# Altium schematic sheet Sensor_template.SchDoc
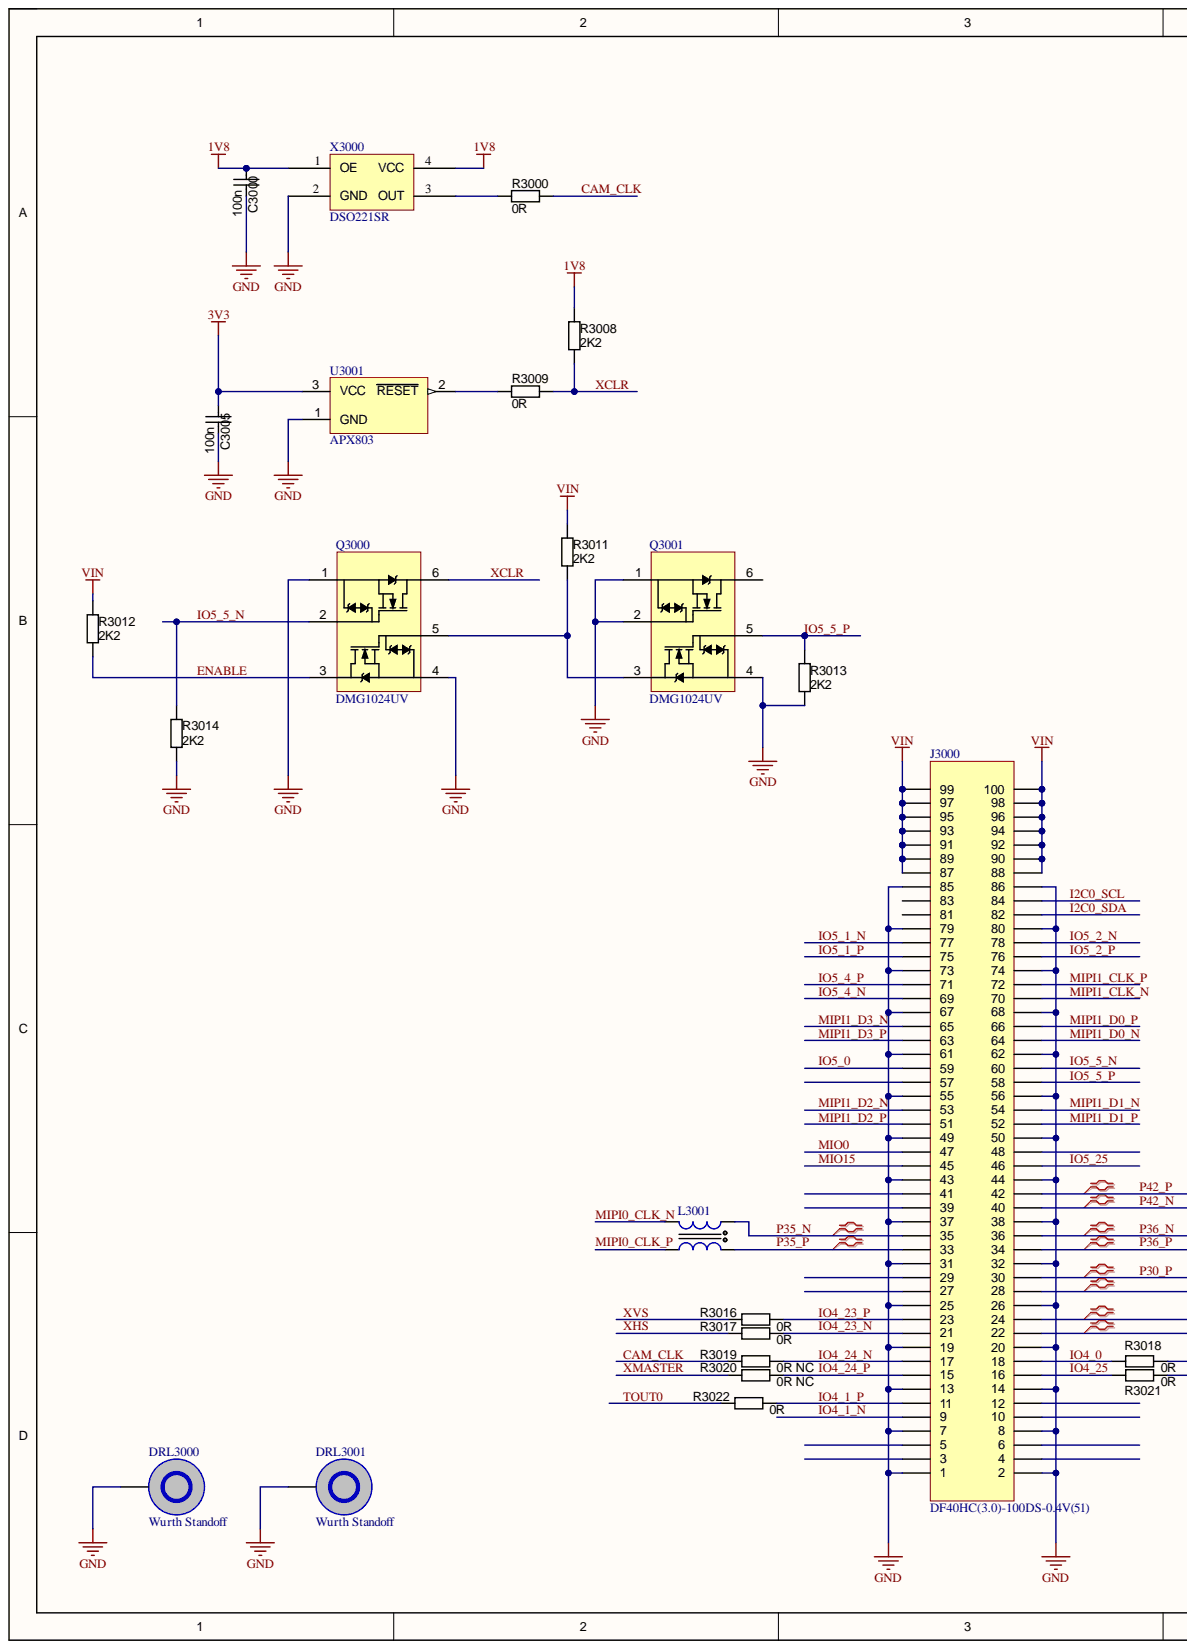
[diagram p0: Sensor_template.SchDoc, left]
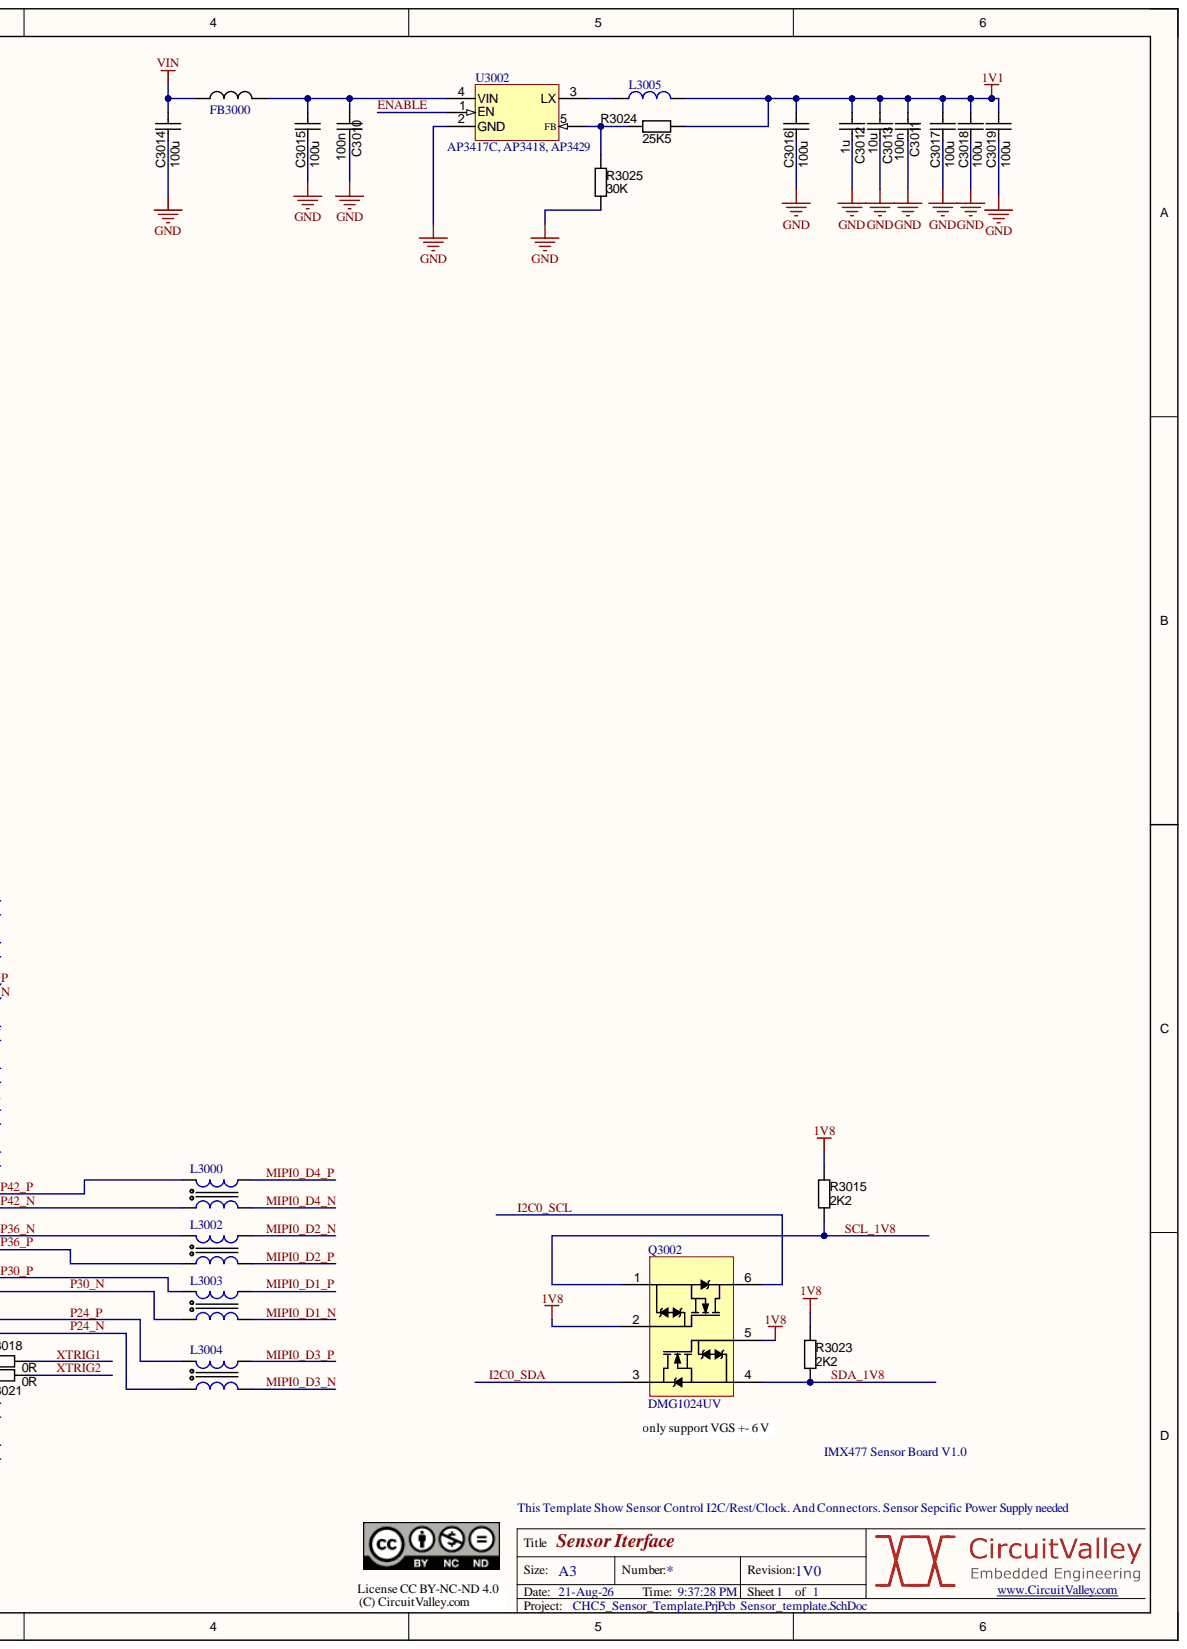
[diagram p1: Sensor_template.SchDoc, right]

## Parts
C3000  100n  [CAPC1005N]
C3005  100n  [CAPC1005N]
C3010  100n  [CAPC1005N]
C3011  100n  [CAPC1005N]
C3012  value 1u  [CAPC1608N]  (hidden comment: approved sub OK)
C3013  value 10u  [CAPC1608N]  (hidden comment: approved sub OK)
C3014  value 100u  [CAPC2012N]  (hidden comment: approved sub OK)
C3015  value 100u  [CAPC2012N]  (hidden comment: approved sub OK)
C3016  value 100u  [CAPC2012N]  (hidden comment: approved sub OK)
C3017  value 100u  [CAPC2012N]  (hidden comment: approved sub OK)
C3018  value 100u  [CAPC2012N]  (hidden comment: approved sub OK)
C3019  value 100u  [CAPC2012N]  (hidden comment: approved sub OK)
DRL3000  Wurth Standoff  [M2_STANDOFF_SMTSOM205BTR]
DRL3001  Wurth Standoff  [M2_STANDOFF_SMTSOM205BTR]
FB3000  Ferrite  [INDC1608N]  (value not drawn)
J3000  DF40HC(3.0)-100DS-0.4V(51)  [DF40HC(3.0)-100DS-0.4V(51)]
L3000  DLM11SN900HZ2L  [DLM11SN900HZ2L]  (value not drawn)
L3001  DLM11SN900HZ2L  [DLM11SN900HZ2L]  (value not drawn)
L3002  DLM11SN900HZ2L  [DLM11SN900HZ2L]  (value not drawn)
L3003  DLM11SN900HZ2L  [DLM11SN900HZ2L]  (value not drawn)
L3004  DLM11SN900HZ2L  [DLM11SN900HZ2L]  (value not drawn)
L3005  DFE252012P-2R2M=P2  [DFE252012P-2R2M=P2]  (value not drawn)
Q3000  DMG1024UV  [SOT563]
Q3001  DMG1024UV  [SOT563]
Q3002  DMG1024UV  [SOT563]
R3000  value 0R  [RESC1005N]  (hidden comment: Thick Film)
R3008  value 2K2  [RESC1005N]  (hidden comment: Thick Film)
R3009  value 0R  [RESC1005N]  (hidden comment: Thick Film)
R3011  value 2K2  [RESC1005N]  (hidden comment: Thick Film)
R3012  value 2K2  [RESC1005N]  (hidden comment: Thick Film)
R3013  value 2K2  [RESC1005N]  (hidden comment: Thick Film)
R3014  value 2K2  [RESC1005N]  (hidden comment: Thick Film)
R3015  value 2K2  [RESC1005N]  (hidden comment: Thick Film)
R3016  value 0R  [RESC1005N]  (hidden comment: Thick Film)
R3017  value 0R  [RESC1005N]  (hidden comment: Thick Film)
R3018  value 0R  [RESC1005N]  (hidden comment: Thick Film)
R3019  value 0R NC  [RESC1005N]  (hidden comment: Thick Film)
R3020  value 0R NC  [RESC1005N]  (hidden comment: Thick Film)
R3021  value 0R  [RESC1005N]  (hidden comment: Thick Film)
R3022  value 0R  [RESC1005N]  (hidden comment: Thick Film)
R3023  value 2K2  [RESC1005N]  (hidden comment: Thick Film)
R3024  value 25K5  [RESC1005N]  (hidden comment: Thick Film)
R3025  value 30K  [RESC1005N]  (hidden comment: Thick Film)
U3001  APX803  [SOT23-3]
U3002  AP3417C, AP3418, AP3429  [SOT23-5]
X3000  DSO221SR  [Active Oscillator 2520]

## Nets
1V1: C3011.2 C3012.1 C3013.1 C3016.1 C3017.1 C3018.1 C3019.1 L3005.2 R3024.2
1V8: C3000.2 Q3002.2 Q3002.5 R3008.1 R3015.2 R3023.2 X3000.1(OE) X3000.4(VCC)
3V3: C3005.2 U3001.3
GND: C3000.1 C3005.1 C3010.1 C3011.1 C3012.2 C3013.2 C3014.2 C3015.2 C3016.2 C3017.2 C3018.2 C3019.2 DRL3000.0 DRL3001.0 J3000.1 J3000.2 J3000.7 J3000.8 J3000.13 J3000.14 J3000.19 J3000.20 J3000.25 J3000.26 J3000.31 J3000.32 J3000.37 J3000.38 J3000.43 J3000.44 J3000.49 J3000.50 J3000.55 J3000.56 J3000.61 J3000.62 J3000.67 J3000.68 J3000.73 J3000.74 J3000.79 J3000.80 J3000.85 J3000.86 Q3000.1 Q3000.4 Q3001.1 Q3001.2 Q3001.4 R3013.1 R3014.2 R3025.1 U3001.1 U3002.2(GND) X3000.2(GND)
VIN: C3014.1 FB3000.1 J3000.87 J3000.88 J3000.89 J3000.90 J3000.91 J3000.92 J3000.93 J3000.94 J3000.95 J3000.96 J3000.97 J3000.98 J3000.99 J3000.100 R3011.2 R3012.1
CAM_CLK: R3000.1 R3019.2
ENABLE: Q3000.3 R3012.2 U3002.1(EN)
I2C0_SCL: J3000.84 Q3002.6
I2C0_SDA: J3000.82 Q3002.3
IO4_0: J3000.18 R3018.2
IO4_1_N: J3000.9
IO4_1_P: J3000.11 R3022.1
IO4_23_N: J3000.21 R3017.1
IO4_23_P: J3000.23 R3016.1
IO4_24_N: J3000.17 R3019.1
IO4_24_P: J3000.15 R3020.1
IO4_25: J3000.16 R3021.2
IO5_0: J3000.59
IO5_1_N: J3000.77
IO5_1_P: J3000.75
IO5_2_N: J3000.78
IO5_2_P: J3000.76
IO5_4_N: J3000.69
IO5_4_P: J3000.71
IO5_5_N: J3000.60 Q3000.2 R3014.1
IO5_5_P: J3000.58 Q3001.5 R3013.2
IO5_25: J3000.46
MIO0: J3000.47
MIO15: J3000.45
MIPI0_CLK_N: L3001.3
MIPI0_CLK_P: L3001.2
MIPI0_D1_N: L3003.2
MIPI0_D1_P: L3003.3
MIPI0_D2_N: L3002.3
MIPI0_D2_P: L3002.2
MIPI0_D3_N: L3004.3
MIPI0_D3_P: L3004.2
MIPI0_D4_N: L3000.2
MIPI0_D4_P: L3000.3
MIPI1_CLK_N: J3000.70
MIPI1_CLK_P: J3000.72
MIPI1_D0_N: J3000.64
MIPI1_D0_P: J3000.66
MIPI1_D1_N: J3000.54
MIPI1_D1_P: J3000.52
MIPI1_D2_N: J3000.53
MIPI1_D2_P: J3000.51
MIPI1_D3_N: J3000.65
MIPI1_D3_P: J3000.63
P24_N: J3000.22 L3004.4
P24_P: J3000.24 L3004.1
P30_N: J3000.28 L3003.1
P30_P: J3000.30 L3003.4
P35_N: J3000.35 L3001.4
P35_P: J3000.33 L3001.1
P36_N: J3000.36 L3002.4
P36_P: J3000.34 L3002.1
P42_N: J3000.40 L3000.1
P42_P: J3000.42 L3000.4
SCL_1V8: Q3002.1 R3015.1
SDA_1V8: Q3002.4 R3023.1
TOUT0: R3022.2
XCLR: Q3000.6 R3008.2 R3009.1
XHS: R3017.2
XMASTER: R3020.2
XTRIG1: R3018.1
XTRIG2: R3021.1
XVS: R3016.2
NetC3010_2: C3010.2 C3015.1 FB3000.2 U3002.4(VIN)
NetL3005_1: L3005.1 U3002.3(LX)
NetQ3000_5: Q3000.5 Q3001.3 R3011.1
NetR3000_2: R3000.2 X3000.3(OUT)
NetR3009_2: R3009.2 U3001.2
NetR3024_1: R3024.1 R3025.2 U3002.5(FB)

Not connected: J3000 3 4 5 6 10 12 27 29 39 41 48 57 81 83; Q3001 6

## Library data of the parts
C3000 C3005 C3010 C3011 = CAPC_10n_0402_16V_10%: Capacitor SMD Cer 10nF 10% 16V X7R 0402; Manufacturer 1: AVX Corporation; Manufacturer Part Number 1: 0402YC103KAT2A; Ratings: 16V; X7R; Component Kind: Standard; ComponentLink1Description: http://www.avx.com/docs/Catalogs/cx7r.pdf; ComponentLink1URL: http://www.avx.com/docs/Catalogs/cx7r.pdf; ComponentLink2Description: http://www.yageo.com/documents/recent/UPY-GPHC_X7R_6.3V-to-50V_8.pdf; ComponentLink2URL: http://www.yageo.com/documents/recent/UPY-GPHC_X7R_6.3V-to-50V_8.pdf; Library Name: PBL - Capacitors.SCHLIB; Manufacturer 2: Yageo; Manufacturer Part Number 2: CC0402KRX7R7BB103; Operating Temperature: -55°C ~ 125°C; Package / Case: 0402 (1005 Metric); Size / Dimension: 0.039" L x 0.020" W (1.00mm x 0.50mm); Supplier 1: Digi-Key; Supplier 2: Digi-Key; Supplier Part Number 1: 478-1114-1-ND; Supplier Part Number 2: 311-1042-1-ND; Tolerance: ±10%; Value: 100n
C3012 C3013 = CAPC_560p_0603_5%: Capacitor SMD Cer 560pF 5% 50V NP0 0603; Manufacturer 1: AVX Corporation; Manufacturer Part Number 1: 06035A561JAT2A; Capacitance: 470pF; Material: ceramic; Ratings: 50V; NP0; Applications: General Purpose; Component Kind: Standard; ComponentLink1Description: http://www.avx.com/docs/Catalogs/ccog.pdf; ComponentLink1URL: http://www.avx.com/docs/Catalogs/ccog.pdf; Height - Seated (Max): -; Lead Spacing: -; Lead Style: -; Library Name: PBL - Capacitors.SCHLIB; Mounting Type: Surface Mount, MLCC; Operating Temperature: -55°C ~ 125°C; Package / Case: 0603 (1608 metric); Size / Dimension: 0.063" L x 0.032" W (1.60mm x 0.81mm); Supplier 1: Digi-Key; Supplier Part Number 1: 478-1184-1-ND; Thickness (Max): 0.035" (0.90mm); Voltage - Rated: 50V
C3014-C3019 = CAPC_1u_0805: Capacitor SMD Cer 1uF 10% 16V X7R 0805; Manufacturer 1: TDK Corporation; Manufacturer Part Number 1: C2012X7R1C105K/1.25; Material: ceramic; Ratings: 16V; X7R; Component Kind: Standard; Library Name: PBL - Capacitors.SCHLIB; Package / Case: 0805 (2012 metric); Supplier 1: Digi-Key; Supplier Part Number 1: 445-1358-1-ND; Tolerance: ±10%
DRL3000 DRL3001 = PCB_Sandoff: Component Kind: Standard; Library Name: cvlib.SchLib
J3000 = DF40HC(3.0)-100DS-0.4V(51): Hiro 100 pin Connector Receptical; Component Kind: Standard; Library Name: cvlib.SchLib
L3000-L3004 = Choke: Component Kind: Standard; Library Name: cvlib.SchLib
L3005 = inductor_smd: Value: 2u2; Component Kind: Standard; Library Name: cvlib.SchLib
R3000 R3008 R3009 R3011-R3025 = RES_3K3_0402_5%: Resistor SMD chip 3.3k Ohm 0.063W 5% 0402; Manufacturer 1: Yageo; Manufacturer Part Number 1: RC0402JR-073K3L; Ratings: 1/16 W; Component Kind: Standard; ComponentLink1Description: http://www.yageo.com/documents/recent/PYu-RC0402_51_RoHS_L_6.pdf; ComponentLink1URL: http://www.yageo.com/documents/recent/PYu-RC0402_51_RoHS_L_6.pdf; Library Name: PBL - Resistors.SCHLIB; Material: Thick Film; Package / Case: 0402 (1005 metric); Supplier 1: Digi-Key; Supplier Part Number 1: 311-3.3KJRCT-ND; Tolerance: ±5%
U3002 = AP3417C: Component Kind: Standard; Library Name: cvlib.SchLib
X3000 = Oscillator_2520: Active Oscillator_2520; Value: 37.125M; Component Kind: Standard; Library Name: Crystal_and_oscillator.SchLib
FB3000 = Ferrite: Component Kind: Standard; Library Name: cvlib.SchLib
Q3000-Q3002 = DMG1024UV: Component Kind: Standard; Description: DMG1024UV; Library Name: cvlib.SchLib
U3001 = APX803: Component Kind: Standard; Library Name: cvlib.SchLib
